# Altium schematic sheet TSAL_Logic.SchDoc(TSAL_Logic)
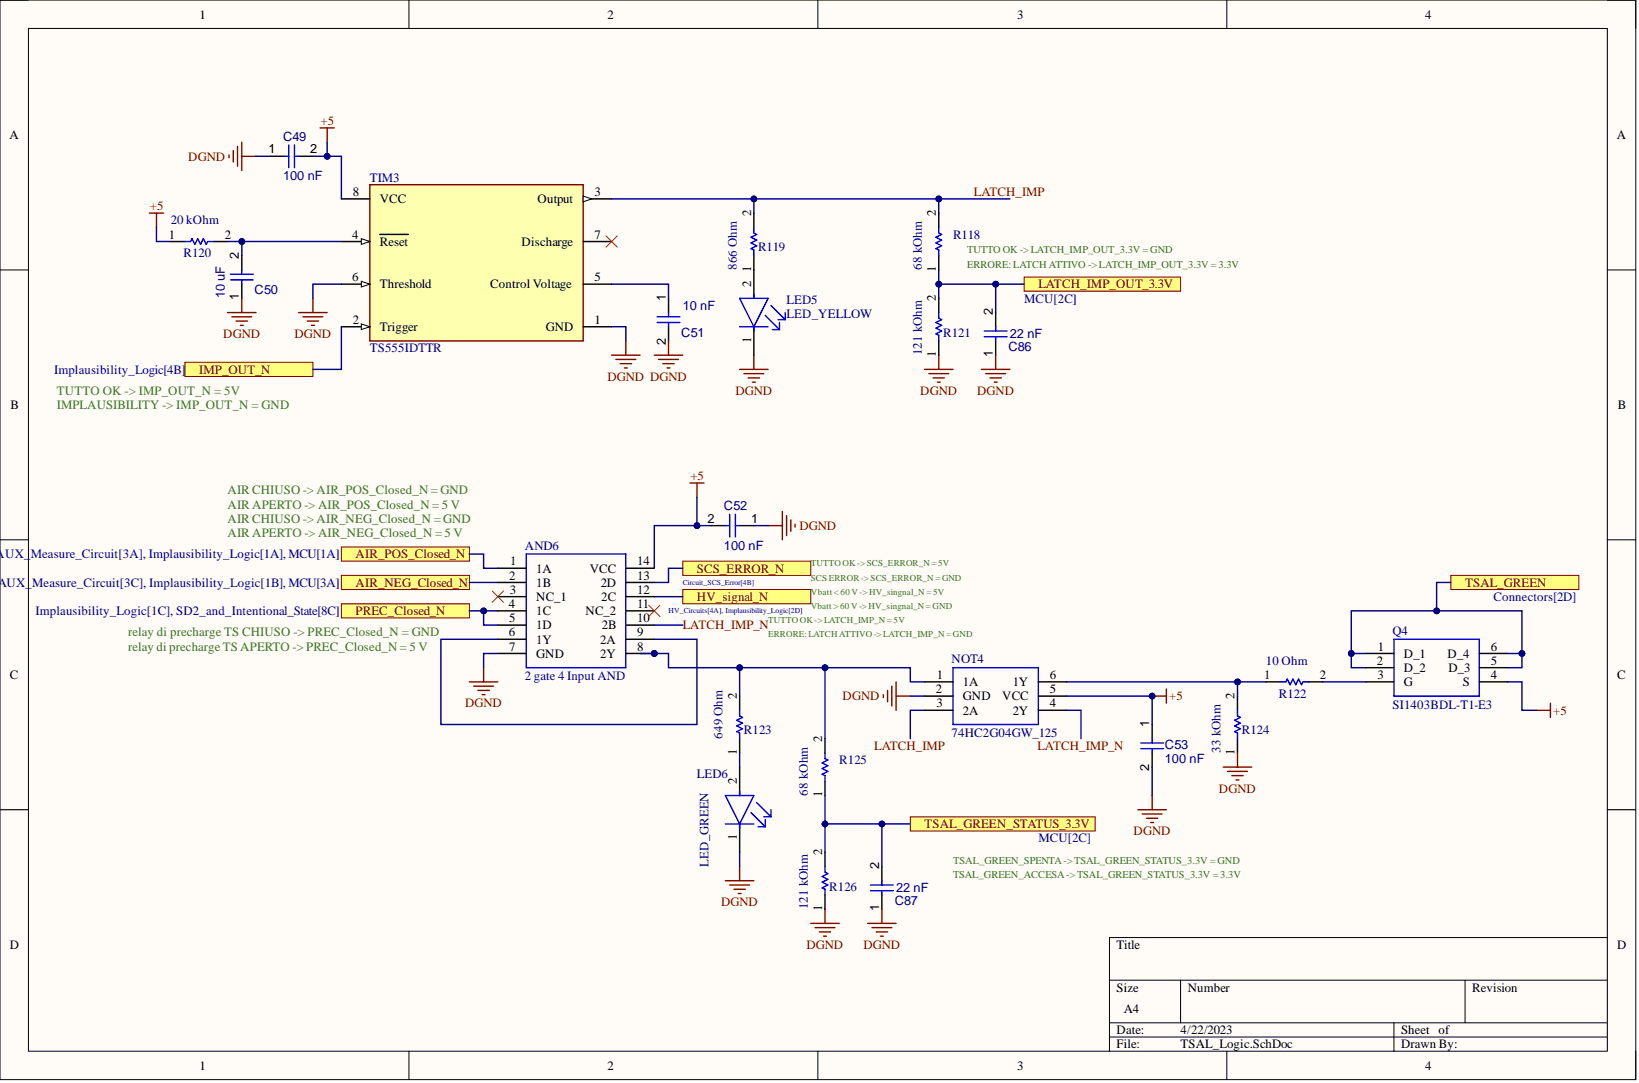
[diagram p0: TSAL_Logic.SchDoc]

## Parts
AND6  2 gate 4 Input AND
C49  100 nF
C50  10 uF
C51  10 nF
C52  100 nF
C53  100 nF
C86  22 nF
C87  22 nF
LED5  LED_YELLOW
LED6  LED_GREEN
NOT4  74HC2G04GW_125
Q4  SI1403BDL-T1-E3
R118  68 kOhm
R119  866 Ohm
R120  20 kOhm
R121  121 kOhm
R122  10 Ohm
R123  649 Ohm
R124  33 kOhm
R125  68 kOhm
R126  121 kOhm
TIM3  TS555IDTTR

## Ports
AIR_NEG_Closed_N, AIR_POS_Closed_N, HV_signal_N, IMP_OUT_N, LATCH_IMP_OUT_3.3V, PREC_Closed_N, SCS_ERROR_N, TSAL_GREEN, TSAL_GREEN_STATUS_3.3V

## Nets
+5: AND6.14(VCC) C49.2 C52.2 C53.1 NOT4.5(VCC) Q4.4(S) R120.1 TIM3.8(VCC)
DGND: AND6.7(GND) C49.1 C50.1 C51.2 C52.1 C53.2 C86.1 C87.1 LED5.1 LED6.1 NOT4.2(GND) R121.1 R124.1 R126.1 TIM3.1(GND) TIM3.6(Threshold)
AIR_NEG_Closed_N: AND6.2(1B) ; port AIR_NEG_Closed_N
AIR_POS_Closed_N: AND6.1(1A) ; port AIR_POS_Closed_N
HV_signal_N: AND6.12(2C) ; port HV_signal_N
IMP_OUT_N: TIM3.2(Trigger) ; port IMP_OUT_N
LATCH_IMP: NOT4.3(2A) R118.2 R119.2 TIM3.3(Output)
LATCH_IMP_N: AND6.10(2B) NOT4.4(2Y)
LATCH_IMP_OUT_3.3V: C86.2 R118.1 R121.2 ; port LATCH_IMP_OUT_3.3V
PREC_Closed_N: AND6.4(1C) AND6.5(1D) ; port PREC_Closed_N
SCS_ERROR_N: AND6.13(2D) ; port SCS_ERROR_N
TSAL_GREEN: Q4.1(D_1) Q4.2(D_2) Q4.5(D_3) Q4.6(D_4) ; port TSAL_GREEN
TSAL_GREEN_STATUS_3.3V: C87.2 R125.1 R126.2 ; port TSAL_GREEN_STATUS_3.3V
NetAND6_6: AND6.6(1Y) AND6.9(2A)
NetAND6_8: AND6.8(2Y) NOT4.1(1A) R123.2 R125.2
NetC50_2: C50.2 R120.2 TIM3.4(Reset)
NetC51_1: C51.1 TIM3.5(Control_Voltage)
NetLED5_2: LED5.2 R119.1
NetLED6_2: LED6.2 R123.1
NetNOT4_6: NOT4.6(1Y) R122.1 R124.2
NetQ4_3: Q4.3(G) R122.2

Not connected: AND6 3(NC_1) 11(NC_2); TIM3 7(Discharge)

## Library data of the parts
AND6 = CMP-002-000004-1: SN74HC21DR; Manufacturer_Name: Texas Instruments; Geometry.Height: 1.75mm; Tech: SMD; Component Kind: Standard; ComponentLink1Description: Website; ComponentLink1URL: https://www.mouser.it/ProductDetail/Texas-Instruments/SN74HC21DR?qs=AgY10sKTvDKk2e%252BAU9w2KA%3D%3D; Datasheet Link: http://www.ti.com/lit/gpn/sn74hc21; Design Item ID: SN74HC21DR; Manufacturer_Part_Number: SN74HC21DR; Mouser Part Number: 595-SN74HC21DR; Mouser Price/Stock: https://www.mouser.co.uk/ProductDetail/Texas-Instruments/SN74HC21DR?qs=AgY10sKTvDKk2e%252BAU9w2KA%3D%3D; Package: SOIC-14; Source: SN74HC21DR.SchLib; Library Name: EMEA- Squadra Corse PoliTO-  Politecnico di Torino
C49 C52 C53 = CMP-001-00085-2: VJ0805Y104MXATW1BC; Tech: SMD; Component Kind: Standard; ComponentLink1Description: Website; ComponentLink1URL: https://www.mouser.it/ProductDetail/KYOCERA-AVX/08055C104KAT4A?qs=2ujN4bDBNE8aFT7CFyBStg%3D%3D; Package: 0805; Library Name: EMEA- Squadra Corse PoliTO-  Politecnico di Torino
C50 = CMP-001-00085-2: GRM21BR71A106KA73K; Tech: SMD; Component Kind: Standard; ComponentLink1Description: Website; ComponentLink1URL: https://www.mouser.it/ProductDetail/Murata-Electronics/GRM21BR61H475KE51L?qs=eeBpzGFlv%252B%252BOtLzDC1PY6Q%3D%3D; Package: 0805; Library Name: EMEA- Squadra Corse PoliTO-  Politecnico di Torino
C51 = CMP-001-00085-2: VJ0805H103KXBBC31; Tech: SMD; Component Kind: Standard; ComponentLink1Description: Website; ComponentLink1URL: https://www.mouser.it/ProductDetail/Vishay-Vitramon/VJ0805H103KXBBC31?qs=ak4kh1ihuH4MS2NjAUlroQ%3D%3D; Package: 0805; Library Name: EMEA- Squadra Corse PoliTO-  Politecnico di Torino
C86 C87 = CMP-001-00085-2: VJ0805Y223JXACW1BC; Tech: SMD; Component Kind: Standard; ComponentLink1Description: Website; ComponentLink1URL: https://www.mouser.it/ProductDetail/Vishay-Vitramon/VJ0805Y223JXXPW1BC?qs=dYENeDlLSFWEZ4cdRF5phA%3D%3D; Package: 0805; Library Name: EMEA- Squadra Corse PoliTO-  Politecnico di Torino
LED5 = CMP-007-00036-1: VLMG1300-GS08; Tech: SMD; Component Kind: Standard; ComponentLink1Description: Website; ComponentLink1URL: https://www.mouser.it/ProductDetail/Vishay-Semiconductors/VLMG1300-GS08?qs=uoVnq4yU%252B9JyXEbHJ6%2FhZQ%3D%3D; Package: 0603; Library Name: EMEA- Squadra Corse PoliTO-  Politecnico di Torino
LED6 = CMP-007-00036-1: VLMTG1401-GS08; Tech: SMD; Component Kind: Standard; ComponentLink1Description: Website; ComponentLink1URL: https://www.mouser.it/ProductDetail/Vishay-Semiconductors/VLMTG1401-GS08?qs=MLItCLRbWsyV58kHttJnog%3D%3D; Package: 0603; Library Name: EMEA- Squadra Corse PoliTO-  Politecnico di Torino
NOT4 = CMP-071-000000-2: 74HC(T)2G04 - Dual inverter@en-us; Tech: SMD; Component Kind: Standard; Package: TSSOP-6; Library Name: EMEA- Squadra Corse PoliTO-  Politecnico di Torino
Q4 = CMP-002-000009-1: SI1403BDL-T1-E3, P-channel MOSFET Transistor 1.4 A 20 V, 6-Pin SOT-363; Manufacturer_Name: Vishay; Geometry.Height: 1.1mm; Component Kind: Standard; Datasheet Link: https://datasheet.datasheetarchive.com/originals/distributors/Datasheets-DGA23/1587687.pdf; Design Item ID: SI1403BDL-T1-E3; Manufacturer_Part_Number: SI1403BDL-T1-E3; Mouser Part Number: 781-SI1403BDL-T1-E3; Mouser Price/Stock: https://www.mouser.co.uk/ProductDetail/Vishay-Semiconductors/SI1403BDL-T1-E3?qs=BuP3DjGpy25sS7bu2zwY4A%3D%3D; Source: SI1403BDL-T1-E3.SchLib; Library Name: EMEA- Squadra Corse PoliTO-  Politecnico di Torino
R118 R125 = CMP-009-00179-4: CRCW060368K0FKEAC; Tech: SMD; Component Kind: Standard; ComponentLink1Description: Website; ComponentLink1URL: https://www.mouser.it/ProductDetail/Vishay-Dale/CRCW060368K0FKEAC?qs=E3Y5ESvWgWOWm3dIamBggg%3D%3D; Package: 0603; Library Name: EMEA- Squadra Corse PoliTO-  Politecnico di Torino
R119 = CMP-009-00179-4: CRCW0603866RFKEA; Tech: SMD; Component Kind: Standard; ComponentLink1Description: Website; ComponentLink1URL: https://www.mouser.it/ProductDetail/Vishay-Dale/CRCW0603866RFKEA?qs=wtJBjE46HQv%2FEQq4OglnCg%3D%3D; Package: 0603; Library Name: EMEA- Squadra Corse PoliTO-  Politecnico di Torino
R120 = CMP-009-00179-4: CRCW060320K0FKEAC; Tech: SMD; Component Kind: Standard; ComponentLink1Description: Website; ComponentLink1URL: https://www.mouser.it/ProductDetail/Vishay-Dale/CRCW060320K0FKEB?qs=%252BZOPz%252B2NLYVQrVjDVZxTrA%3D%3D; Package: 0603; Library Name: EMEA- Squadra Corse PoliTO-  Politecnico di Torino
R121 R126 = CMP-009-00179-4: CRCW0603121KFKEA; Tech: SMD; Component Kind: Standard; ComponentLink1Description: Website; ComponentLink1URL: https://www.mouser.it/ProductDetail/Vishay-Dale/CRCW0603121KFKEA?qs=SNwsiWikH8rxaNKbUsRIDA%3D%3D; Package: 0603; Library Name: EMEA- Squadra Corse PoliTO-  Politecnico di Torino
R122 = CMP-009-00179-4: CRCW060310R0FKEAHP; Tech: SMD; Component Kind: Standard; ComponentLink1Description: Website; ComponentLink1URL: https://www.mouser.it/ProductDetail/Vishay-Dale/CRCW060310R0FKEAHP?qs=k2%2FDWSARqgHKJ7AdmQKyZw%3D%3D; Package: 0603; Library Name: EMEA- Squadra Corse PoliTO-  Politecnico di Torino
R123 = CMP-009-00179-4: CRCW0603649RFKEA; Tech: SMD; Component Kind: Standard; ComponentLink1Description: Website; ComponentLink1URL: https://www.mouser.it/ProductDetail/Vishay-Dale/CRCW0603649RFKEA?qs=iNbzBj8pBh1tTN7VNgR8HQ%3D%3D; Package: 0603; Library Name: EMEA- Squadra Corse PoliTO-  Politecnico di Torino
R124 = CMP-009-00179-4: RCS060333K0FKEA; Tech: SMD; Component Kind: Standard; ComponentLink1Description: Website; ComponentLink1URL: https://www.mouser.it/ProductDetail/Vishay-Dale/RCS060333K0FKEA?qs=yDQnu9hAfI9uIG1%252BkBaBdg%3D%3D; Package: 0603; Library Name: EMEA- Squadra Corse PoliTO-  Politecnico di Torino
TIM3 = CMP-12094-000001-1: Manufacturer: STMicroelectronics; Frequency: 2.7MHz; Max Junction Temp: +150°C; Max Supply Voltage: 16V; Min Supply Voltage: 2V; Nominal Supply Current: 65-170uA; Number Of Timers/Counters: 1; Propagation Delay: 100ns; Standoff Height: 0.1mm; Tech: SMD; Ambient Temperature Range High: +125°C; Ambient Temperature Range Low: -40°C; Automotive: No; Category: IC; Component Kind: Standard; ComponentLink2Description: Datasheet; ComponentLink2URL: https://www.st.com/resource/en/datasheet/ts555.pdf; ComponentLink3Description: Website; ComponentLink3URL: https://www.mouser.it/ProductDetail/STMicroelectronics/TS555IDTTR?qs=ypY7uveMeOSn6Z3Y4YsQ%252BQ%3D%3D; Description: TS555IDTTR; Device Class L1: Integrated Circuits (ICs); Device Class L2: Clock and Timing; Device Class L3: Timers and Oscillators; Digikey Description: IC OSC SINGLE TIMER 2.7MHZ 8SOIC; Footprint Url: https://www.st.com/resource/en/datasheet/stm8l001j3.pdf; Height: 1.75mm; Lead Free: Yes; Library Name: Altium Content Vault; Manufacturer Part Number: TS555IDTTR; Mouser Description: Standard Timer Single -40C 125C 8-Pin SO N T/R; Octopart: https://octopart.com/ts555idttr-stmicroelectronics-64758051; Package: SO8; RoHS: TRUE; Temperature: -40°C to +125°C; Temperature Range High: +125°C; Temperature Range Low: -40°C
